# Altium schematic sheet BOARD_POWER.SchDoc
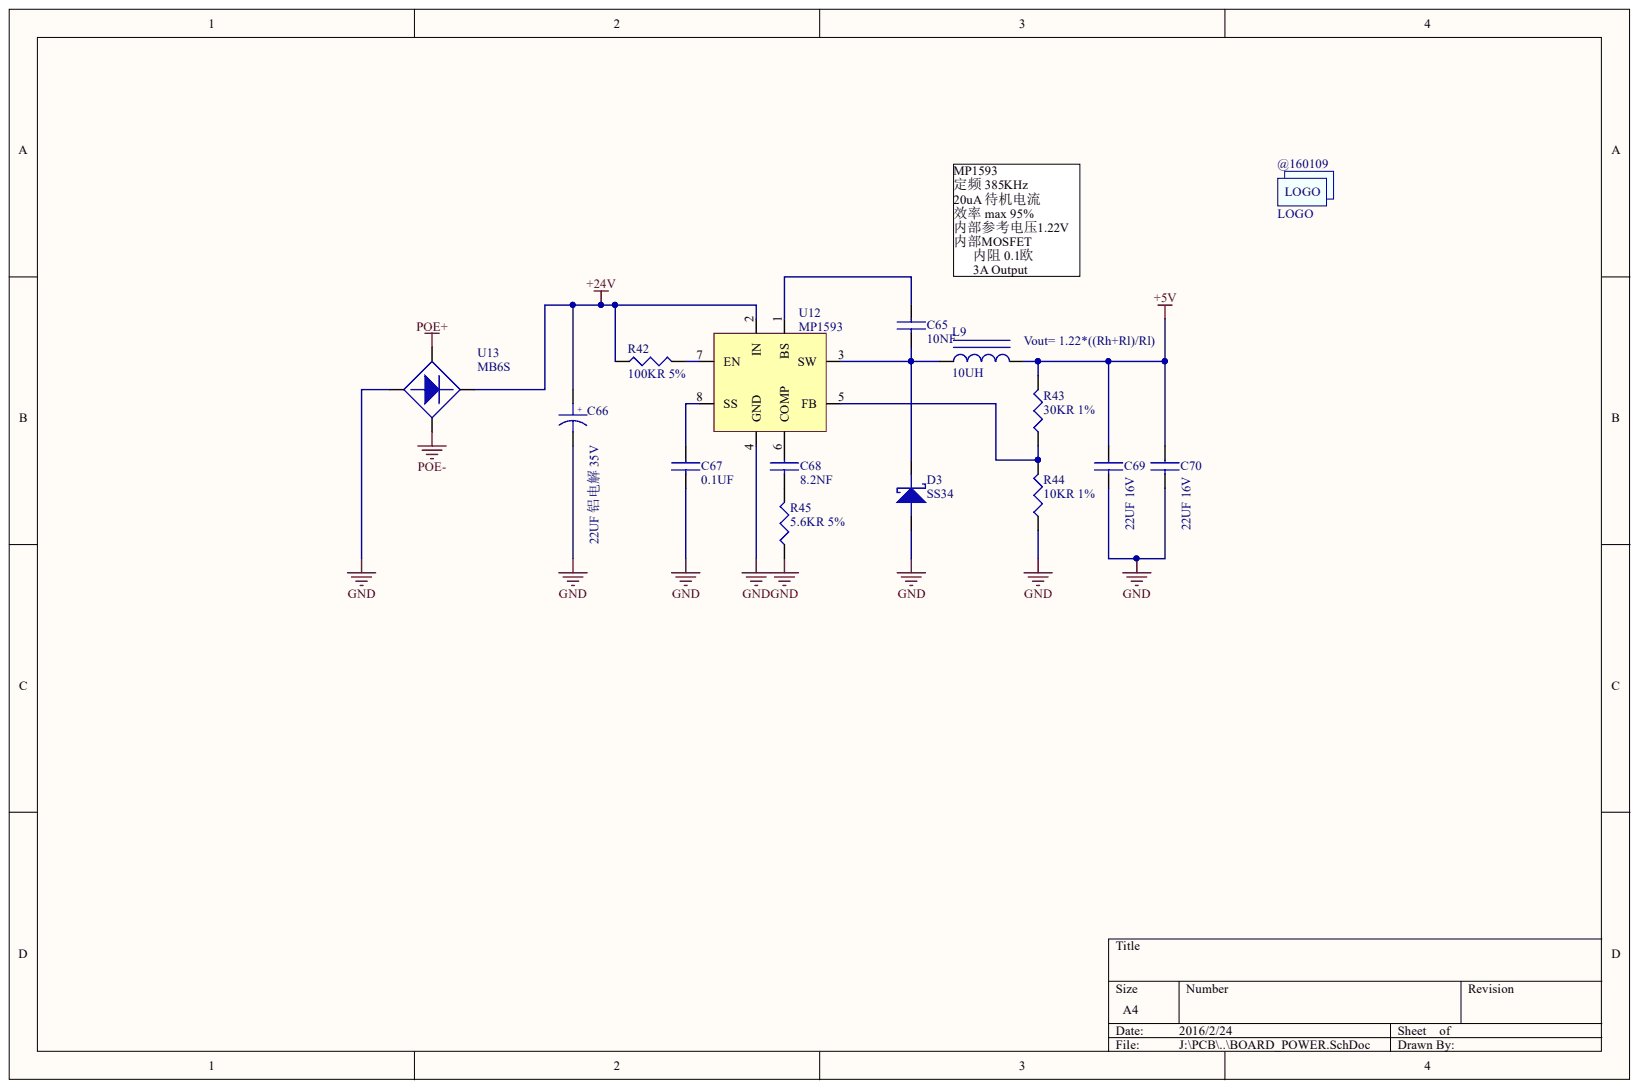
[diagram p0: BOARD_POWER.SchDoc]

## Parts
@160109  LOGO  [LOGO3]
C65  10NF  [CAPC1005X05N]
C66  22UF 铝电解 35V  [CAP630X540_400]
C67  0.1UF  [CAPC1005X05N]
C68  8.2NF  [CAPC1005X05N]
C69  22UF 16V  [CAPC3216X140N]
C70  22UF 16V  [CAPC3216X140N]
D3  SS34  [SOD123_370X125-2N]
L9  10UH  [INDCP700X700-400]
R42  100KR 5%  [RESC1005X04N]
R43  30KR 1%  [RESC1005X04N]
R44  10KR 1%  [RESC1005X04N]
R45  5.6KR 5%  [RESC1005X04N]
U12  MP1593  [SOIC127P600X150_HS-9N]
U13  MB6S  [SOIC260P700X300-4N]

## Nets
+5V: C69.1 C70.1 L9.2 R43.2
+24V: C66.1 R42.1 U12.2(IN) U13.3
GND: C66.2 C67.2 C69.2 C70.2 D3.A R44.1 R45.1 U12.4(GND) U13.4
POE+: U13.1
POE-: U13.2
NetC65_1: C65.1 U12.1(BS)
NetC65_2: C65.2 D3.K L9.1 U12.3(SW)
NetC67_1: C67.1 U12.8(SS)
NetC68_1: C68.1 U12.6(COMP)
NetC68_2: C68.2 R45.2
NetR42_2: R42.2 U12.7(EN)
NetR43_1: R43.1 R44.2 U12.5(FB)

## Library data of the parts
C65 C67-C70 = CAP: Capacitor nonpolarity; Component Kind: Standard; Library Name: RCL_Standard.SchLib
C66 = CAPACITOR POL: Capacitor polarity; Component Kind: Standard; Library Name: RCL_Standard.SchLib
D3 = DIODE SCHOTTKY: Diode schottky (肖特基),SS34..; Component Kind: Standard; Library Name: RCL_Standard.SchLib
L9 = INDUCTOR IRON: Component Kind: Standard; Library Name: RCL_Standard.SchLib
R42-R45 = R: General Resistors; Component Kind: Standard; Library Name: RCL_Standard.SchLib
U12 = MP1593: Power IC,DC_DC; Component Kind: Standard; Library Name: IC.SchLib
U13 = MB6S: 桥堆; Component Kind: Standard; Library Name: IC.SchLib
@160109 = LOGO: Component Kind: Standard; Description: LOGO; Library Name: Other.SchLib
